# Altium schematic sheet P06_Ethernet.SchDoc
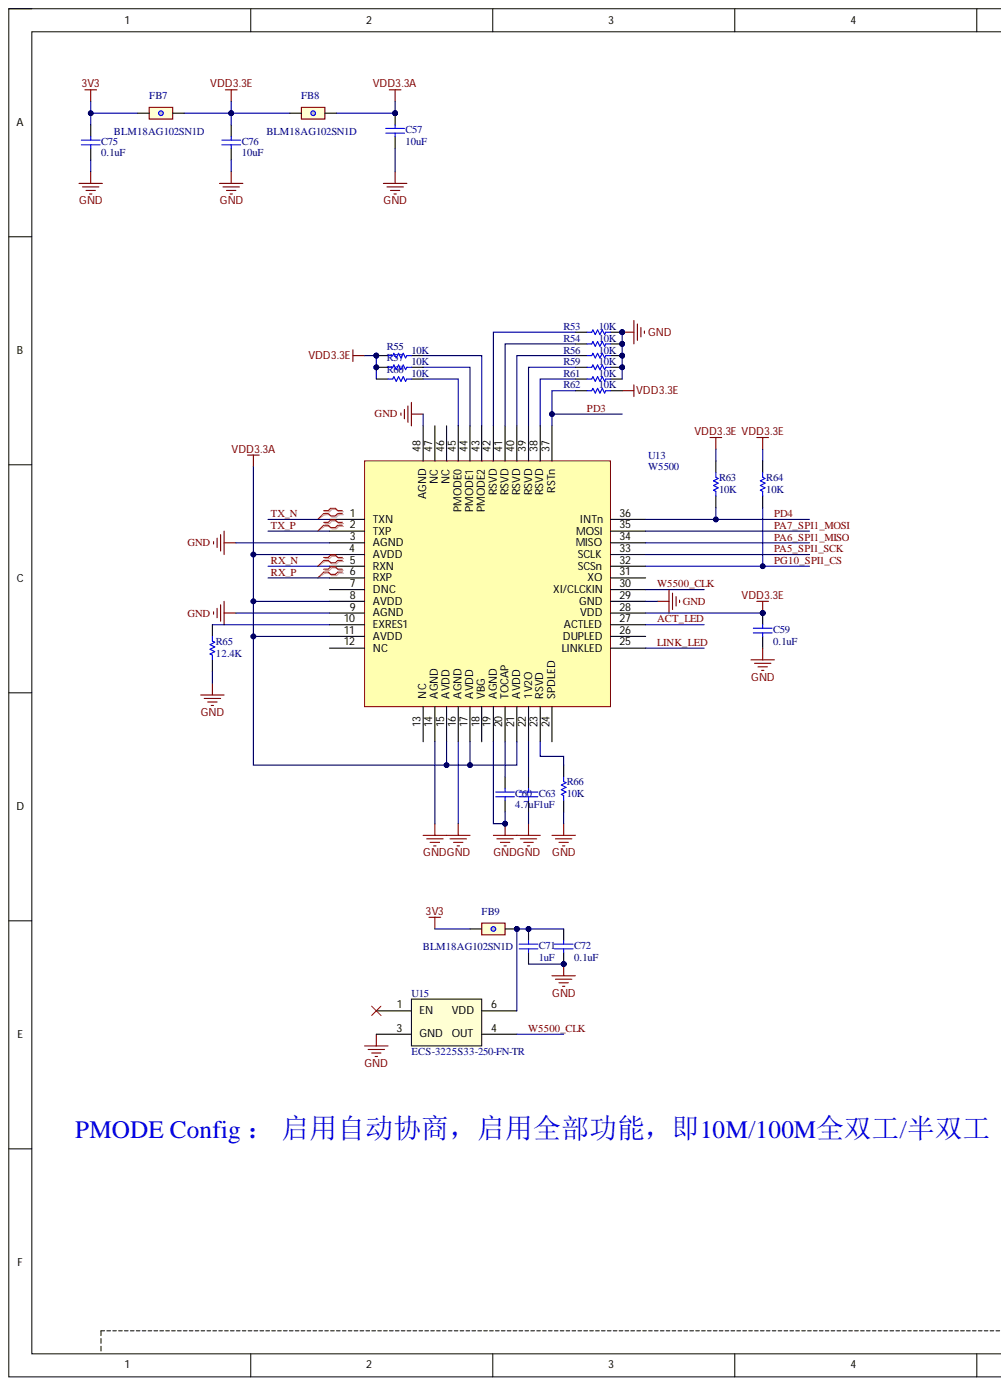
[diagram p0: P06_Ethernet.SchDoc, left]
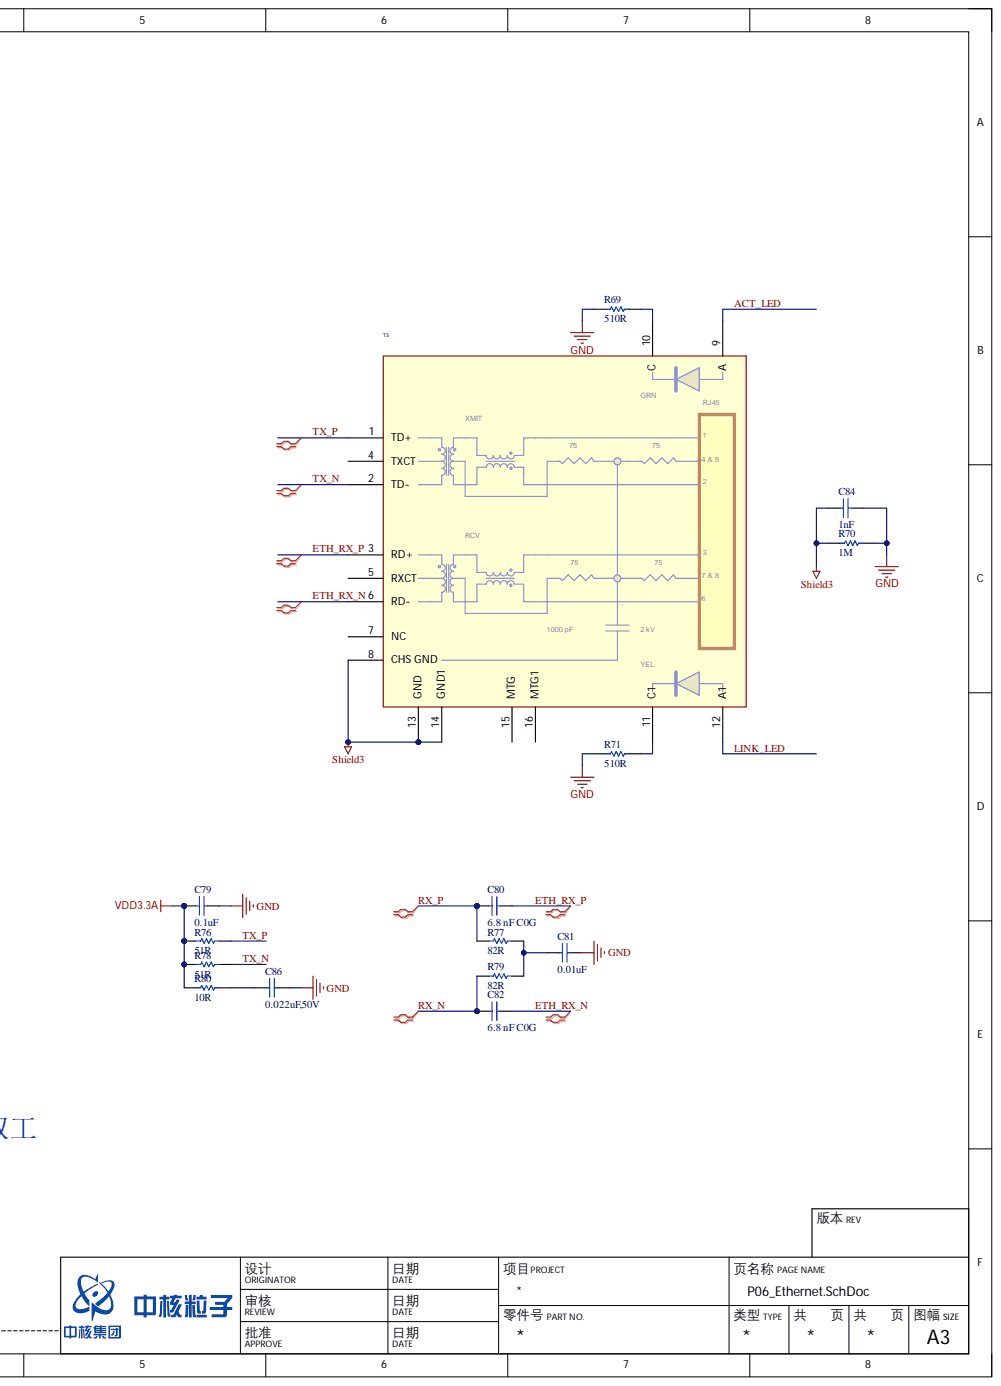
[diagram p1: P06_Ethernet.SchDoc, right]

## Parts
C57  10uF  [C0805(2012)]
C59  0.1uF  [C0603(1608)]
C60  4.7uF  [C0603(1608)]
C63  1uF  [C0603(1608)]
C71  1uF  [C0603(1608)]
C72  0.1uF  [C0603(1608)]
C75  0.1uF  [C0603(1608)]
C76  10uF  [C0805(2012)]
C79  0.1uF  [C0603(1608)]
C80  6.8 nF C0G  [C0603(1608)]
C81  0.01uF  [C0603(1608)]
C82  6.8 nF C0G  [C0603(1608)]
C84  1nF  [C1206(3216)]
C86  0.022uF,50V  [C0603(1608)]
FB7  BLM18AG102SN1D  [R0603(1608)]
FB8  BLM18AG102SN1D  [R0603(1608)]
FB9  BLM18AG102SN1D  [R0603(1608)]
R53  10K  [R0603(1608)]
R54  10K  [R0603(1608)]
R55  10K  [R0603(1608)]
R56  10K  [R0603(1608)]
R57  10K  [R0603(1608)]
R59  10K  [R0603(1608)]
R60  10K  [R0603(1608)]
R61  10K  [R0603(1608)]
R62  10K  [R0603(1608)]
R63  10K  [R0603(1608)]
R64  10K  [R0603(1608)]
R65  12.4K  [R0603(1608)]
R66  10K  [R0603(1608)]
R69  510R  [R0603(1608)]
R70  1M  [R1206(3216)]
R71  510R  [R0603(1608)]
R76  51R  [R0603(1608)]
R77  82R  [R0603(1608)]
R78  51R  [R0603(1608)]
R79  82R  [R0603(1608)]
R80  10R  [R0603(1608)]
T3  J0011D01BNL  [J0011D01BNL]  (value not drawn)
U13  W5500  [W5500]
U15  ECS-3225S33-250-FN-TR  [ECS-3225S33-250-FN-TR]

## Nets
3V3: C75.2 FB7.1 FB9.1
GND: C57.1 C59.1 C60.1 C63.1 C71.1 C72.1 C75.1 C76.1 C79.1 C81.2 C84.2 C86.1 R53.2 R54.2 R56.2 R59.2 R61.2 R65.1 R66.1 R69.1 R70.2 R71.1 U13.3(AGND) U13.9(AGND) U13.14(AGND) U13.16(AGND) U13.19(AGND) U13.29(GND) U13.48(AGND) U15.3(GND)
Shield3: C84.1 R70.1 T3.8(CHS_GND) T3.13(GND) T3.14(GND1)
VDD3.3A: C57.2 C79.2 FB8.2 R76.1 R78.1 R80.1 U13.4(AVDD) U13.8(AVDD) U13.11(AVDD) U13.15(AVDD) U13.17(AVDD) U13.21(AVDD)
VDD3.3E: C59.2 C76.2 FB7.2 FB8.1 R55.2 R57.2 R60.2 R62.1 R63.2 R64.2 U13.28(VDD)
ACT_LED: T3.9(A) U13.27(ACTLED)
ETH_RX_N: C82.2 T3.6(RD-)
ETH_RX_P: C80.2 T3.3(RD+)
LINK_LED: T3.12(A1) U13.25(LINKLED)
PA5_SPI1_SCK: U13.33(SCLK)
PA6_SPI1_MISO: U13.34(MISO)
PA7_SPI1_MOSI: U13.35(MOSI)
PD3: R62.2 U13.37(RSTn)
PD4: R63.1 U13.36(INTn)
PG10_SPI1_CS: R64.1 U13.32(SCSn)
RX_N: C82.1 R79.1 U13.5(RXN)
RX_P: C80.1 R77.1 U13.6(RXP)
TX_N: R78.2 T3.2(TD-) U13.1(TXN)
TX_P: R76.2 T3.1(TD+) U13.2(TXP)
W5500_CLK: U13.30(XI/CLCKIN) U15.4(OUT)
NetC60_2: C60.2 U13.20(TOCAP)
NetC63_2: C63.2 U13.22(1V2O)
NetC71_2: C71.2 C72.2 FB9.2 U15.6(VDD)
NetC81_1: C81.1 R77.2 R79.2
NetC86_2: C86.2 R80.2
NetR53_1: R53.1 U13.42(RSVD)
NetR54_1: R54.1 U13.41(RSVD)
NetR55_1: R55.1 U13.43(PMODE2)
NetR56_1: R56.1 U13.40(RSVD)
NetR57_1: R57.1 U13.44(PMODE1)
NetR59_1: R59.1 U13.39(RSVD)
NetR60_1: R60.1 U13.45(PMODE0)
NetR61_1: R61.1 U13.38(RSVD)
NetR65_2: R65.2 U13.10(EXRES1)
NetR66_2: R66.2 U13.23(RSVD)
NetR69_2: R69.2 T3.10(C)
NetR71_2: R71.2 T3.11(C1)

Not connected: T3 4(TXCT) 5(RXCT) 7(NC) 15(MTG) 16(MTG1); U13 7(DNC) 12(NC) 13(NC) 18(VBG) 24(SPDLED) 26(DUPLED) 31(XO) 46(NC) 47(NC); U15 1(EN)

## Library data of the parts
C57 C76 = Cap 10uF 0805-25V: ceramic 25V; 价格: ¥1.44@250; 制造商: KEMET; 型号: C0805C106K3PACTU; Component Kind: Standard; Library Name: RCL.SchLib; 供应商及库存编号: e络盟 2491176
C59 C75 C79 = Cap 0.1uF 0603: ceramic 25V; 价格: ￥0.092@100; 制造商: KEMET; 型号: C0603C104K3RACTU; Component Kind: Standard; Library Name: RCL.SchLib; 供应商及库存编号: e络盟 1650835
C60 = Cap 4.7uF 0603-10V: ceramic 10V; 价格: ¥0.88@10; 制造商: MURATA; 型号: GRM188R61A475KE15D; Component Kind: Standard; Library Name: RCL.SchLib; 供应商及库存编号: e络盟  2362098
C63 = Cap 1uF 0603-50V: ceramic 50V; 价格: ¥1.96@100; 制造商: TDK; 型号: CGA3E3X5R1H105K080AB; Component Kind: Standard; Library Name: RCL.SchLib; 供应商及库存编号: e络盟 2210922
C71 = Cap 1uF 0603-1: ceramic 10V; 价格: ¥0.11@100; 制造商: KEMET; 型号: C0603C105K8PACTU; Component Kind: Standard; Library Name: RCL.SchLib; 供应商及库存编号: e络盟 9227776
C72 = Cap 0.1uF 0603: ceramic 25V; 价格: ¥0.092@100; 制造商: KEMET; 型号: C0603C104K3RACTU; Component Kind: Standard; Library Name: RCL.SchLib; 供应商及库存编号: e络盟 1650835
C80 C82 = Cap 6.8nF 0603-50V: ceramic 50V 5% C0G; 价格: ¥0.62@100; 制造商: TDK; 型号: C1608C0G1H682J080AA; Component Kind: Standard; Library Name: RCL.SchLib; 供应商及库存编号: e络盟  1907313
C81 = Cap 0.01uF 0603-50V: ceramic 50V; 价格: ¥0.03@100; 制造商: MULTICOMP; 型号: MC0603B103M500CT; Component Kind: Standard; Library Name: RCL.SchLib; 供应商及库存编号: e络盟 1709948
C84 = Cap 1nF 1206-2KV: ceramic 2KV; 价格: ¥0.504@100; 制造商: MULTICOMP; 型号: MC1206B102K202CT; Component Kind: Standard; Library Name: RCL.SchLib; 供应商及库存编号: e络盟  1855909
C86 = Cap 0.022uF 0603-50V: ceramic 50V; 价格: ¥0.13@150; 制造商: YAGEO; 型号: CC0603KRX7R9BB223; Component Kind: Standard; Library Name: RCL.SchLib; 供应商及库存编号: e络盟 3019755RL
FB7 FB8 = FB-1K@100MHz: 0603; 价格: ￥0.25@100; 制造商: MURATA; Component Kind: Standard; Library Name: RCL.SchLib; 供应商及库存编号: e络盟 1515671; 型号: BLM18AG102SN1D
FB9 = FB-1K@100MHz: 0603; 价格: ¥0.25@100; 制造商: MURATA; Component Kind: Standard; Library Name: RCL.SchLib; 供应商及库存编号: e络盟 1515671; 型号: BLM18AG102SN1D
R53-R57 R59-R64 R66 = Res 10K 0603: Res ±1%; 名称: 贴片电阻; Component Kind: Standard; Library Name: RCL.SchLib
R65 = Res 12.4K 0603: Res ±1%; Component Kind: Standard; Library Name: RCL.SchLib
R69 R71 = Res 510R 0603: Res ±1%; Component Kind: Standard; Library Name: RCL.SchLib
R70 = Res 1M 1206: Res ±1%; Component Kind: Standard; Library Name: RCL.SchLib
R76 R78 = Res 51R 0603: Res ±1%; Component Kind: Standard; Library Name: RCL.SchLib
R77 R79 = Res 82R 0603: Res ±1%; Component Kind: Standard; Library Name: RCL.SchLib
R80 = Res 10R 0603: Res ±1%; Component Kind: Standard; Library Name: RCL.SchLib
T3 = J0011D01BNL: MAGJACK 1PORT 100 BASE-TX; 价格: $6.27@100; 制造商: Pulse Electronics Network; Component Kind: Standard; Library Name: Connector.SchLib; 供应商及库存编号: digikey 553-1483-ND; 型号: J0011D01BNL
U13 = W5500_[C32843]: 以太网; Component Kind: Standard; ComponentLink1Description: 供应商链接; ComponentLink1URL: http://www.szlcsc.com/product/details_33808.html; Library Name: IC2023.SchLib; Package: LQFP-48_7x7x05P; Supplier: LC; SuppliersPartNumber: C32843
U15 = ECS-3225S33-250-FN-TR: OSC XO 25.0000MHZ HCMOS SMD; 价格: $0.755@1K; 制造商: ECS Inc.; Component Kind: Standard; Library Name: IC.SchLib; 供应商及库存编号: digikey XC2221TR-ND; 型号: ECS-3225S33-250-FN-TR
